# Altium schematic sheet 4-PPS.SchDoc(4-PPS)
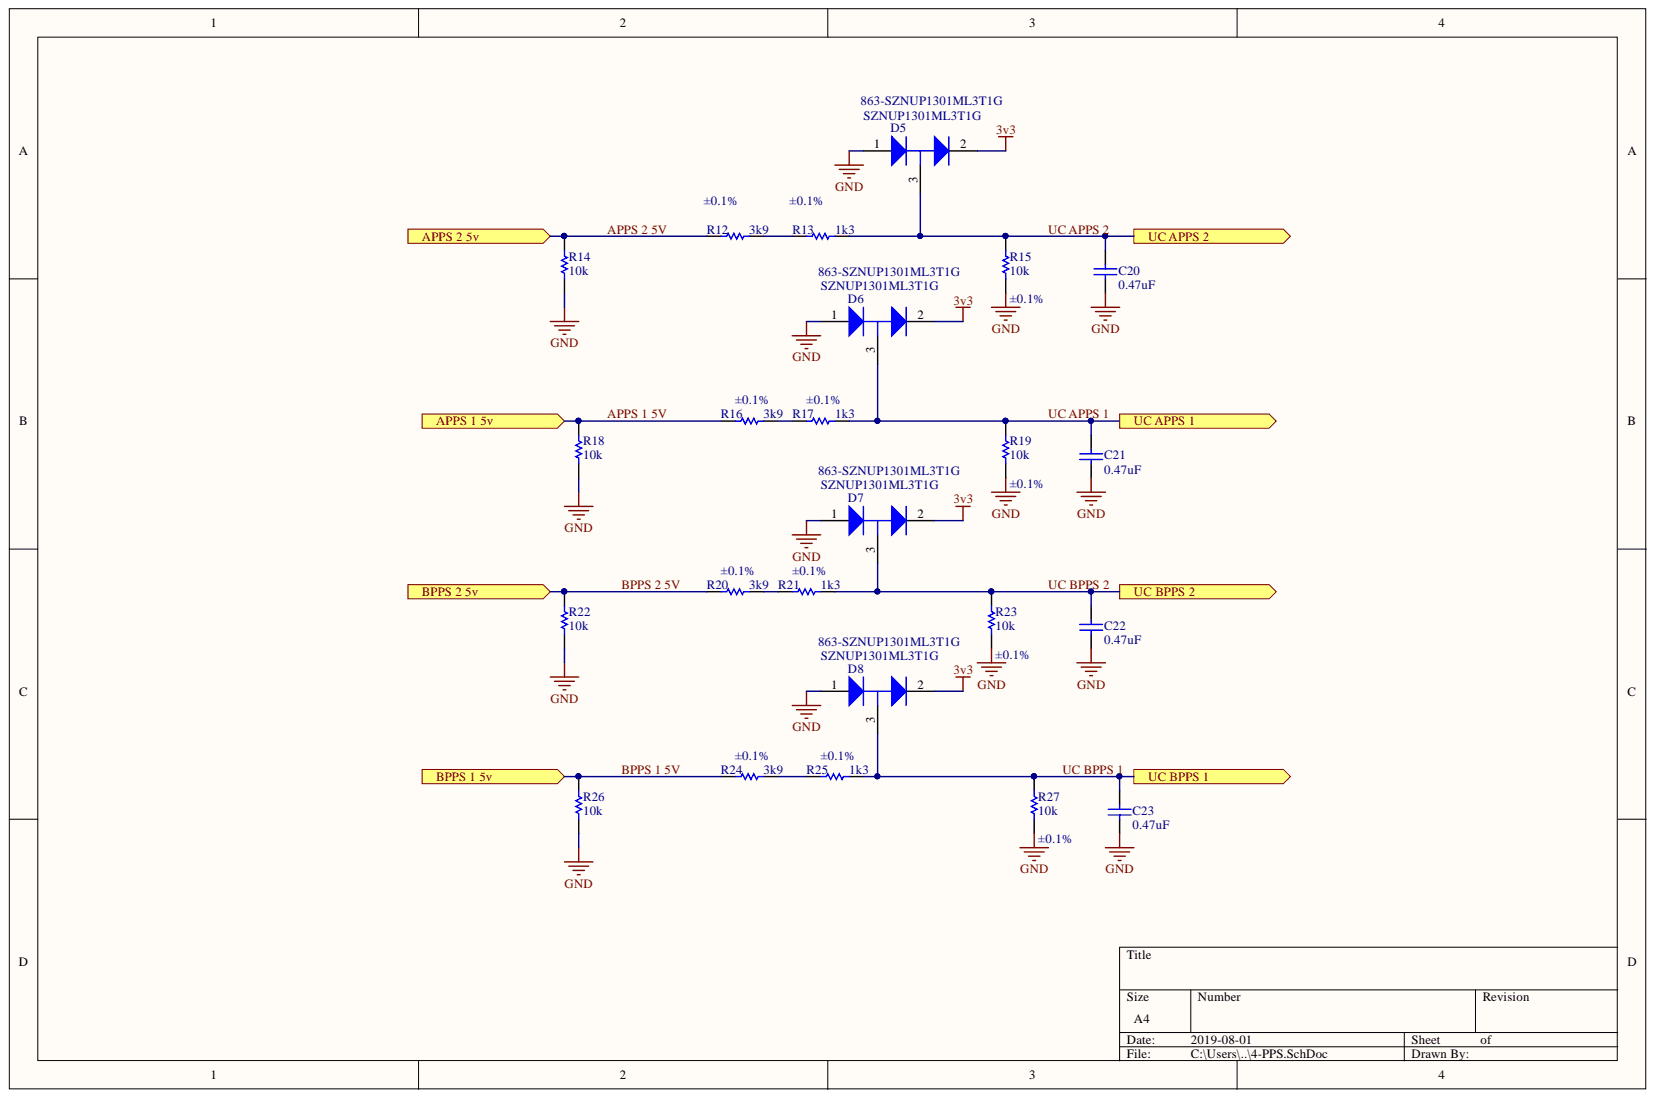
[diagram p0: 4-PPS.SchDoc]

## Parts
C20  value 0.47uF  [1608[0603]]  (hidden comment: Cap)
C21  value 0.47uF  [1608[0603]]  (hidden comment: Cap)
C22  value 0.47uF  [1608[0603]]  (hidden comment: Cap)
C23  value 0.47uF  [1608[0603]]  (hidden comment: Cap)
D5  SZNUP1301ML3T1G  [ONSC-SOT-23-3-318-08_V]
D6  SZNUP1301ML3T1G  [ONSC-SOT-23-3-318-08_V]
D7  SZNUP1301ML3T1G  [ONSC-SOT-23-3-318-08_V]
D8  SZNUP1301ML3T1G  [ONSC-SOT-23-3-318-08_V]
R12  value 3k9  Tolerance: ±0.1%  [1608[0603]]  (hidden comment: Res1)
R13  value 1k3  Tolerance: ±0.1%  [1608[0603]]  (hidden comment: Res1)
R14  value 10k  [1608[0603]]  (hidden comment: Res1)
R15  value 10k  Tolerance: ±0.1%  [1608[0603]]  (hidden comment: Res1)
R16  value 3k9  Tolerance: ±0.1%  [1608[0603]]  (hidden comment: Res1)
R17  value 1k3  Tolerance: ±0.1%  [1608[0603]]  (hidden comment: Res1)
R18  value 10k  [1608[0603]]  (hidden comment: Res1)
R19  value 10k  Tolerance: ±0.1%  [1608[0603]]  (hidden comment: Res1)
R20  value 3k9  Tolerance: ±0.1%  [1608[0603]]  (hidden comment: Res1)
R21  value 1k3  Tolerance: ±0.1%  [1608[0603]]  (hidden comment: Res1)
R22  value 10k  [1608[0603]]  (hidden comment: Res1)
R23  value 10k  Tolerance: ±0.1%  [1608[0603]]  (hidden comment: Res1)
R24  value 3k9  Tolerance: ±0.1%  [1608[0603]]  (hidden comment: Res1)
R25  value 1k3  Tolerance: ±0.1%  [1608[0603]]  (hidden comment: Res1)
R26  value 10k  [1608[0603]]  (hidden comment: Res1)
R27  value 10k  Tolerance: ±0.1%  [1608[0603]]  (hidden comment: Res1)

## Ports
APPS 1 5v, APPS 2 5v, BPPS 1 5v, BPPS 2 5v, UC APPS 1, UC APPS 2, UC BPPS 1, UC BPPS 2

## Nets
3v3: D5.2 D6.2 D7.2 D8.2
GND: C20.1 C21.1 C22.1 C23.1 D5.1 D6.1 D7.1 D8.1 R14.1 R15.1 R18.1 R19.1 R22.1 R23.1 R26.1 R27.1
APPS 1 5V: R16.1 R18.2 ; port APPS 1 5v
APPS 2 5V: R12.1 R14.2 ; port APPS 2 5v
BPPS 1 5V: R24.1 R26.2 ; port BPPS 1 5v
BPPS 2 5V: R20.1 R22.2 ; port BPPS 2 5v
UC APPS 1: C21.2 D6.3 R17.2 R19.2 ; port UC APPS 1
UC APPS 2: C20.2 D5.3 R13.2 R15.2 ; port UC APPS 2
UC BPPS 1: C23.2 D8.3 R25.2 R27.2 ; port UC BPPS 1
UC BPPS 2: C22.2 D7.3 R21.2 R23.2 ; port UC BPPS 2
NetR12_2: R12.2 R13.1
NetR16_2: R16.2 R17.1
NetR20_2: R20.2 R21.1
NetR24_2: R24.2 R25.1

## Library data of the parts
C20-C23 = Cap: Capacitor; Manufacturer Part Number: CC0603KRX7R8BB474; Manufacturer: Yageo; Voltage - Rated: 25V; Tolerance: ±10%; Temperature Coefficient: X7R; Capacitance: 0.47µF; Thickness (Max): 0.035" (0.90mm); Supplier Part Number 1: 311-1805-1-ND; Supplier 1: Digi-Key; Stock 1: 11076; Size / Dimension: 0.063" L x 0.031" W (1.60mm x 0.80mm); Simulation: CAP; Signal Integrity: Cap; RoHS: RoHS Compliant; Ratings: -; Pricing 1: 1=0.22, 10=0.158, 25=0.1156, 50=0.0898, 100=0.0745, 250=0.06168, 500=0.05226, 1000=0.04324 (CAD); Part Status: Active; Packaging: Cut Tape (CT); Package / Case: 0603 (1608 Metric); Operating Temperature: -55°C ~ 125°C; Mounting Type: Surface Mount, MLCC; Library Name: Miscellaneous Devices.IntLib; Lead Style: -; Lead Spacing: -; Height - Seated (Max): -; Features: -; Failure Rate: -; ComponentLink1URL: http://www.yageo.com/documents/recent/UPY-GPHC_X7R_6.3V-to-50V_16.pdf; ComponentLink1Description: http://www.yageo.com/documents/recent/UPY-GPHC_X7R_6.3V-to-50V_16.pdf; Component Kind: Standard; Category: Capacitors; Applications: General Purpose
D5-D8 = CMP-1063-00171-1: Low Capacitance Diode Array for ESD Protection in a Single Data Line, 3-Pin SOT-23, Pb-Free, Tape and Reel; Manufacturer: On Semiconductor; VRWM Max (V): 70; Number of Lines: 1; Direction: Bidirectional; C Max (pF): 0.9; V(BR) Min (V): 70; Supplier Part Number 1: 863-SZNUP1301ML3T1G; Supplier 1: Mouser; RoHS: Pb-Free; PartNumber: SZNUP1301ML3T1G; Packing: Tape and Reel; PackageVersion: Rev. AP, 11/2009; PackageReference: SOT-23-3-318-08; PackageDescription: 3-Pin Small Outline Transistor, Body 2.92 x 1.3 mm, Pitch 0.95 mm; Mounting Technology: Surface Mount; Library Name: Altium Content Vault; DatasheetVersion: Rev. 6; ComponentLink3URL: http://www.onsemi.cn/pub_link/Collateral/318-08.PDF; ComponentLink3Description: Package Specification; ComponentLink2URL: http://www.onsemi.cn/pub_link/Collateral/NUP1301ML3T1-D.PDF; ComponentLink2Description: Datasheet; ComponentLink1URL: http://www.onsemi.com/; ComponentLink1Description: Manufacturer URL; Component Kind: Standard
R12 R16 R20 R24 = Res1: Resistor; Manufacturer Part Number: RT0603BRD073K9L; Manufacturer: Yageo; Temperature Coefficient: ±25ppm/°C; Resistance (Ohms): 3.9k; Power (Watts): 0.1W, 1/10W; Supplier Part Number 1: YAG1656CT-ND; Supplier Device Package: 0603; Supplier 1: Digi-Key; Stock 1: 10688; Size / Dimension: 0.063" L x 0.031" W (1.60mm x 0.80mm); Simulation: RESISTOR; Signal Integrity: Res; RoHS: RoHS Compliant; Pricing 1: 1=0.55, 10=0.464, 25=0.3376, 50=0.2446, 100=0.1814, 250=0.1392, 500=0.10966, 1000=0.07592 (CAD); Part Status: Active; Packaging: Cut Tape (CT); Package / Case: 0603 (1608 Metric); Operating Temperature: -55°C ~ 155°C; Number of Terminations: 2; Library Name: Miscellaneous Devices.IntLib; Height - Seated (Max): 0.022" (0.55mm); Features: Moisture Resistant; Failure Rate: -; Composition: Thin Film; ComponentLink1URL: http://www.yageo.com/documents/recent/PYu-RT_1-to-0.05_RoHS_L_6.pdf; ComponentLink1Description: http://www.yageo.com/documents/recent/PYu-RT_1-to-0.05_RoHS_L_6.pdf; Component Kind: Standard; Category: Resistors
R13 R17 R21 R25 = Res1: Resistor; Manufacturer Part Number: RT0603BRD071K3L; Manufacturer: Yageo; Temperature Coefficient: ±25ppm/°C; Resistance (Ohms): 1.3k; Power (Watts): 0.1W, 1/10W; Supplier Part Number 1: YAG1565CT-ND; Supplier Device Package: 0603; Supplier 1: Digi-Key; Stock 1: 2236; Size / Dimension: 0.063" L x 0.031" W (1.60mm x 0.80mm); Simulation: RESISTOR; Signal Integrity: Res; RoHS: RoHS Compliant; Pricing 1: 1=0.55, 10=0.464, 25=0.3376, 50=0.2446, 100=0.1814, 250=0.1392, 500=0.10966, 1000=0.07592 (CAD); Part Status: Active; Packaging: Cut Tape (CT); Package / Case: 0603 (1608 Metric); Operating Temperature: -55°C ~ 155°C; Number of Terminations: 2; Library Name: Miscellaneous Devices.IntLib; Height - Seated (Max): 0.022" (0.55mm); Features: Moisture Resistant; Failure Rate: -; Composition: Thin Film; ComponentLink1URL: http://www.yageo.com/documents/recent/PYu-RT_1-to-0.05_RoHS_L_6.pdf; ComponentLink1Description: http://www.yageo.com/documents/recent/PYu-RT_1-to-0.05_RoHS_L_6.pdf; Component Kind: Standard; Category: Resistors
R14 R18 R22 R26 = Res1: Resistor; Manufacturer Part Number: RC0603JR-0710KL; Manufacturer: Yageo; Tolerance: ±5%; Temperature Coefficient: ±100ppm/°C; Power (Watts): 0.1W, 1/10W; Supplier Part Number 1: 311-10KGRCT-ND; Supplier Device Package: 0603; Supplier 1: Digi-Key; Stock 1: 2936292; Size / Dimension: 0.063" L x 0.031" W (1.60mm x 0.80mm); Simulation: RESISTOR; Signal Integrity: Res; RoHS: RoHS Compliant; Resistance (Ohms): 10k; Pricing 1: 1=0.16, 10=0.016, 25=0.0112, 50=0.0084, 100=0.0062, 250=0.0048, 500=0.00382, 1000=0.00281 (CAD); Part Status: Active; Packaging: Cut Tape (CT); Package / Case: 0603 (1608 Metric); Operating Temperature: -55°C ~ 155°C; Number of Terminations: 2; Library Name: Miscellaneous Devices.IntLib; Height - Seated (Max): 0.022" (0.55mm); Features: Moisture Resistant; Failure Rate: -; Composition: Thick Film; ComponentLink1URL: http://www.yageo.com/NewPortal/yageodocoutput?fileName=/pdf/R-Chip/PYu-RC_Group_51_RoHS_L_4.pdf; ComponentLink1Description: http://www.yageo.com/NewPortal/yageodocoutput?fileName=/pdf/R-Chip/PYu-RC_Group_51_RoHS_L_4.pdf; Component Kind: Standard; Category: Resistors
R15 R19 R23 R27 = Res1: Resistor; Manufacturer Part Number: RT0603BRD0710KL; Manufacturer: Yageo; Temperature Coefficient: ±25ppm/°C; Power (Watts): 0.1W, 1/10W; Supplier Part Number 1: YAG1236CT-ND; Supplier Device Package: 0603; Supplier 1: Digi-Key; Stock 1: 2958; Size / Dimension: 0.063" L x 0.031" W (1.60mm x 0.80mm); Simulation: RESISTOR; Signal Integrity: Res; RoHS: RoHS Compliant; Resistance (Ohms): 10k; Pricing 1: 1=0.5, 10=0.42, 25=0.3048, 50=0.2212, 100=0.164, 250=0.12592, 500=0.0992, 1000=0.06867 (CAD); Part Status: Active; Packaging: Cut Tape (CT); Package / Case: 0603 (1608 Metric); Operating Temperature: -55°C ~ 155°C; Number of Terminations: 2; Library Name: Miscellaneous Devices.IntLib; Height - Seated (Max): 0.022" (0.55mm); Features: Moisture Resistant; Failure Rate: -; Composition: Thin Film; ComponentLink1URL: http://www.yageo.com/documents/recent/PYu-RT_1-to-0.05_RoHS_L_6.pdf; ComponentLink1Description: http://www.yageo.com/documents/recent/PYu-RT_1-to-0.05_RoHS_L_6.pdf; Component Kind: Standard; Category: Resistors
